# Altium schematic sheet CPU.SchDoc(CPU)
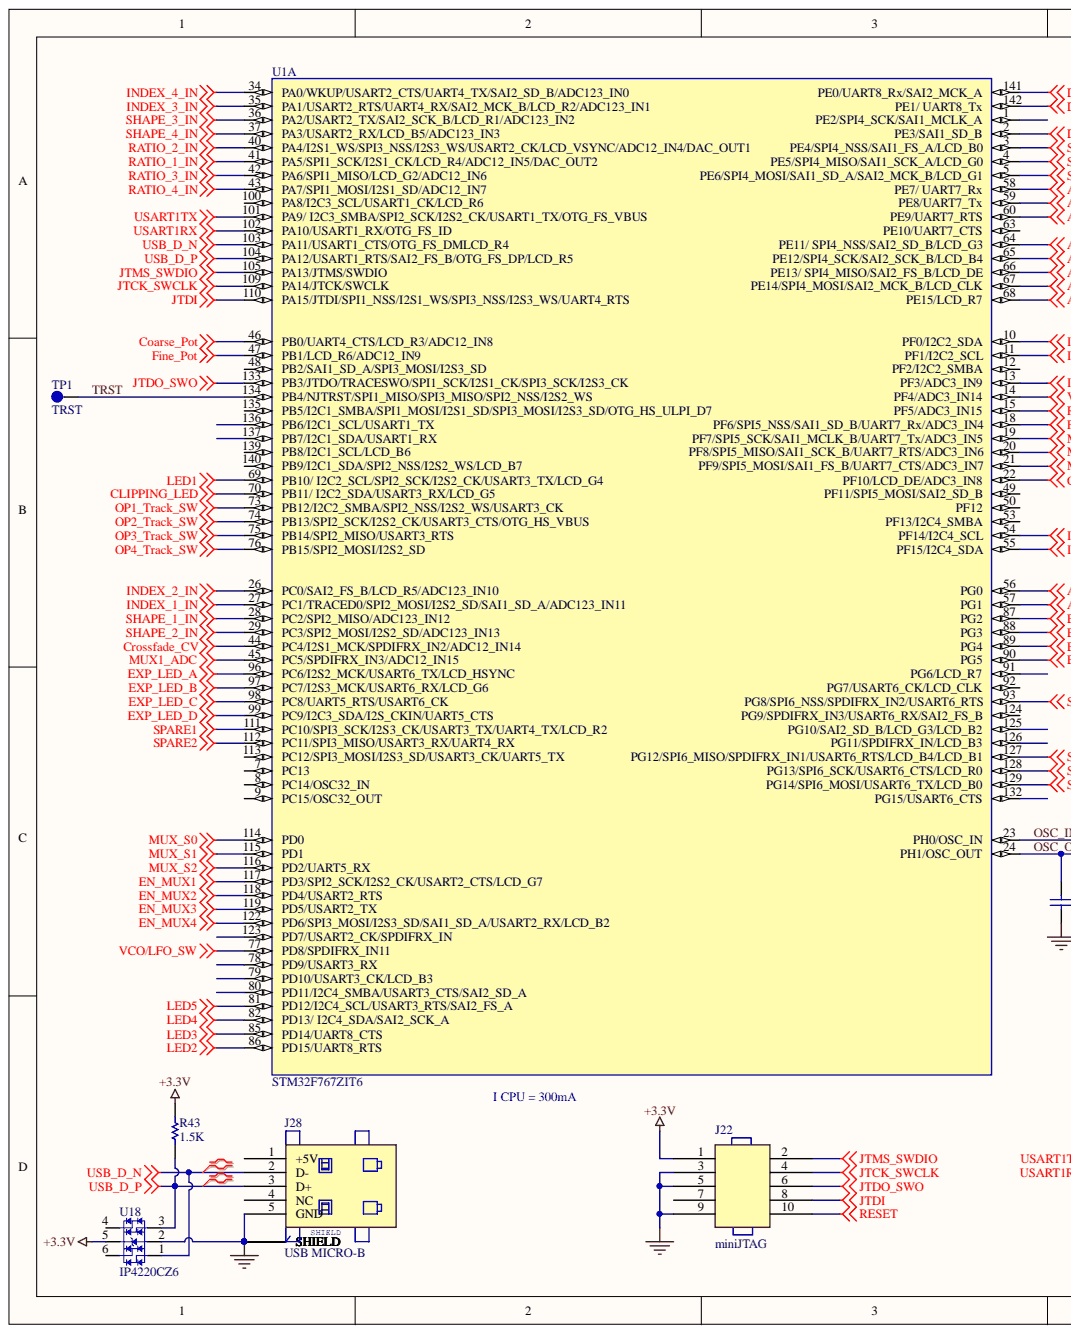
[diagram p0: CPU.SchDoc, left]
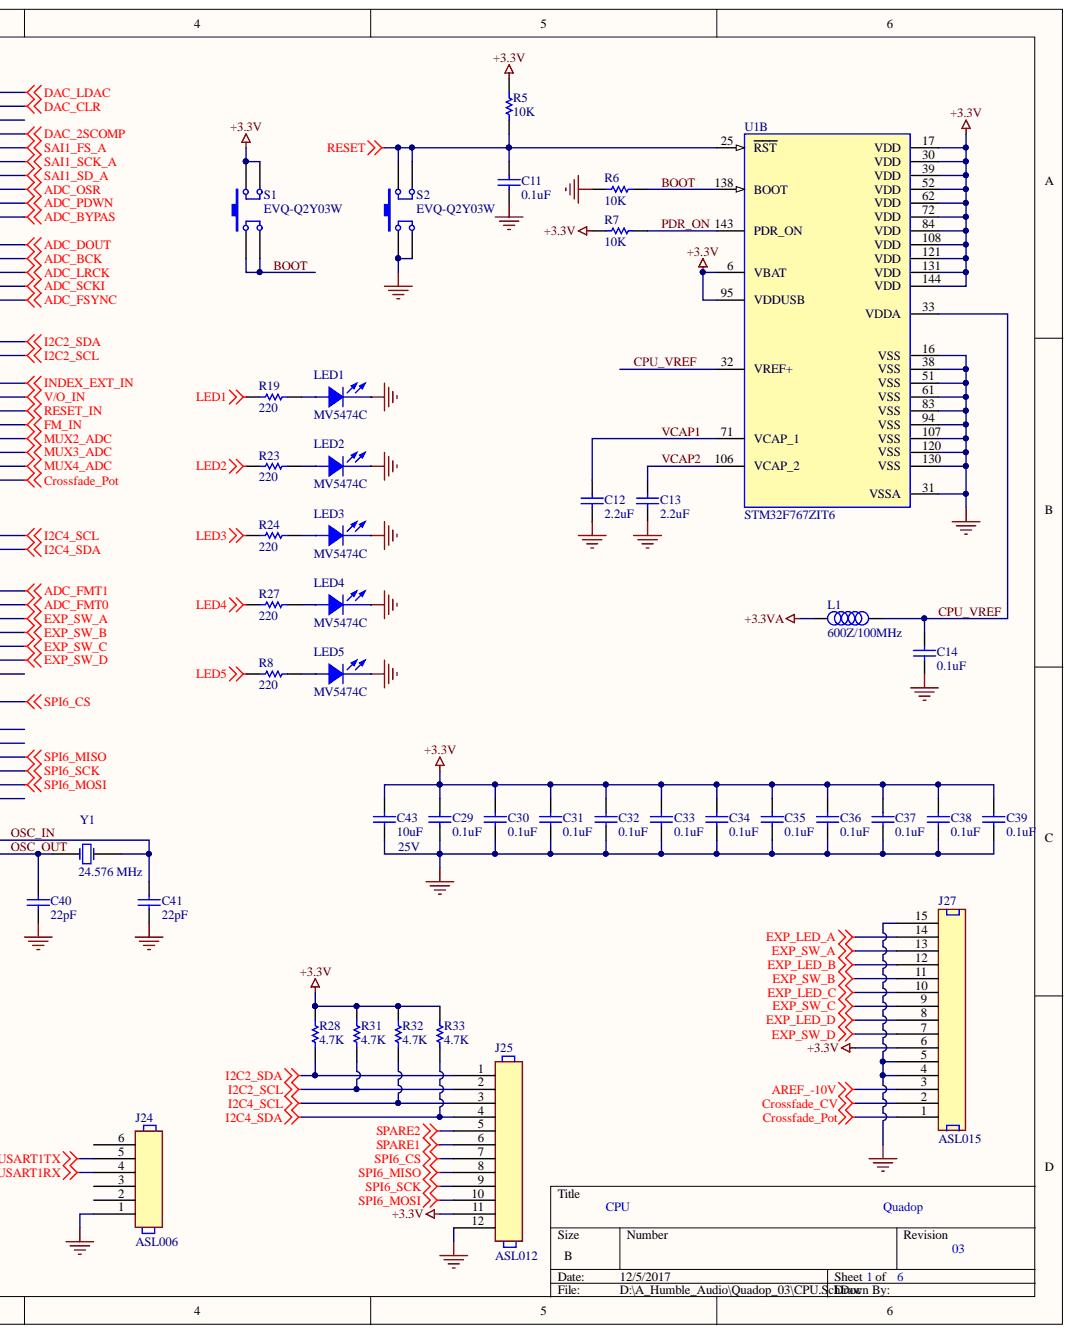
[diagram p1: CPU.SchDoc, right]

## Parts
C11  0.1uF  [C0603]
C12  2.2uF  [C0603]
C13  2.2uF  [C0603]
C14  0.1uF  [C0603]
C29  0.1uF  [C0603]
C30  0.1uF  [C0603]
C31  0.1uF  [C0603]
C32  0.1uF  [C0603]
C33  0.1uF  [C0603]
C34  0.1uF  [C0603]
C35  0.1uF  [C0603]
C36  0.1uF  [C0603]
C37  0.1uF  [C0603]
C38  0.1uF  [C0603]
C39  0.1uF  [C0603]
C40  22pF  [C0603]
C41  22pF  [C0603]
C43  10uF  Voltage: 25V  [C0805]
J22  miniJTAG  [HDR_1.27_2x5]
J24  ASL006  [SL1X6]
J25  ASL012  [SL1X12]
J27  ASL015  [SL1X15]
J28  USB MICRO-B  Part Type: USB  [Molex_1050170001]
L1  600Z/100MHz  [L1608]
LED1  MV5474C  [VDLED2_5XF3.2]
LED2  MV5474C  [VDLED2_5XF3.2]
LED3  MV5474C  [VDLED2_5XF3.2]
LED4  MV5474C  [VDLED2_5XF3.2]
LED5  MV5474C  [VDLED2_5XF3.2]
R5  10K  [R0603]
R6  10K  [R0603]
R7  10K  [R0603]
R8  220  [R0603]
R19  220  [R0603]
R23  220  [R0603]
R24  220  [R0603]
R27  220  [R0603]
R28  4.7K  [R0603]
R31  4.7K  [R0603]
R32  4.7K  [R0603]
R33  4.7K  [R0603]
R43  1.5K  [R0603]
S1  EVQ-Q2Y03W  [TACT_EVQQ2_3.1mm]
S2  EVQ-Q2Y03W  [TACT_EVQQ2_3.1mm]
TP1  TRST  [TP_D0.6_SMD]
U1  STM32F767ZIT6  [LQFP144_0.5_20X20]  (parts A,B)
U18  IP4220CZ6  [SC74]
Y1  24.576 MHz  [Y2_5X3.2]

## Nets
+3.3V: C29.1 C30.1 C31.1 C32.1 C33.1 C34.1 C35.1 C36.1 C37.1 C38.1 C39.1 C43.1 J22.1 J25.11 J27.6 R5.1 R7.2 R28.1 R31.1 R32.1 R33.1 R43.1 S1.3 S1.4 U1.6(VBAT) U1.17(VDD) U1.30(VDD) U1.39(VDD) U1.52(VDD) U1.62(VDD) U1.72(VDD) U1.84(VDD) U1.95(VDDUSB) U1.108(VDD) U1.121(VDD) U1.131(VDD) U1.144(VDD) U18.5
+3.3VA: L1.1
ADC_BCK: U1.65(PE12/SPI4_SCK/SAI2_SCK_B/LCD_B4)
ADC_BYPAS: U1.60(PE9/UART7_RTS)
ADC_DOUT: U1.64(PE11/_SPI4_NSS/SAI2_SD_B/LCD_G3)
ADC_FMT0: U1.57(PG1)
ADC_FMT1: U1.56(PG0)
ADC_FSYNC: U1.68(PE15/LCD_R7)
ADC_LRCK: U1.66(PE13/_SPI4_MISO/SAI2_FS_B/LCD_DE)
ADC_OSR: U1.58(PE7/_UART7_Rx)
ADC_PDWN: U1.59(PE8/UART7_Tx)
ADC_SCKI: U1.67(PE14/SPI4_MOSI/SAI2_MCK_B/LCD_CLK)
AREF_-10V: J27.3
CLIPPING_LED: U1.70(PB11/_I2C2_SDA/USART3_RX/LCD_G5)
Coarse_Pot: U1.46(PB0/UART4_CTS/LCD_R3/ADC12_IN8)
Crossfade_CV: J27.2 U1.44(PC4/I2S1_MCK/SPDIFRX_IN2/ADC12_IN14)
Crossfade_Pot: J27.1 U1.22(PF10/LCD_DE/ADC3_IN8)
DAC_2SCOMP: U1.2(PE3/SAI1_SD_B)
DAC_CLR: U1.142(PE1/_UART8_Tx)
DAC_LDAC: U1.141(PE0/UART8_Rx/SAI2_MCK_A)
EN_MUX1: U1.117(PD3/SPI2_SCK/I2S2_CK/USART2_CTS/LCD_G7)
EN_MUX2: U1.118(PD4/USART2_RTS)
EN_MUX3: U1.119(PD5/USART2_TX)
EN_MUX4: U1.122(PD6/SPI3_MOSI/I2S3_SD/SAI1_SD_A/USART2_RX/LCD_B2)
EXP_LED_A: J27.14 U1.96(PC6/I2S2_MCK/USART6_TX/LCD_HSYNC)
EXP_LED_B: J27.12 U1.97(PC7/I2S3_MCK/USART6_RX/LCD_G6)
EXP_LED_C: J27.10 U1.98(PC8/UART5_RTS/USART6_CK)
EXP_LED_D: J27.8 U1.99(PC9/I2C3_SDA/I2S_CKIN/UART5_CTS)
EXP_SW_A: J27.13 U1.87(PG2)
EXP_SW_B: J27.11 U1.88(PG3)
EXP_SW_C: J27.9 U1.89(PG4)
EXP_SW_D: J27.7 U1.90(PG5)
Fine_Pot: U1.47(PB1/LCD_R6/ADC12_IN9)
FM_IN: U1.18(PF6/SPI5_NSS/SAI1_SD_B/UART7_Rx/ADC3_IN4)
GND: C11.2 C12.2 C13.2 C14.2 C29.2 C30.2 C31.2 C32.2 C33.2 C34.2 C35.2 C36.2 C37.2 C38.2 C39.2 C40.2 C41.2 C43.2 J22.3 J22.5 J22.9 J24.1 J25.12 J27.4 J27.5 J27.15 J28.5(GND) J28.6 J28.7 J28.8 J28.9 J28.10(SHIELD) J28.11 LED1.2 LED2.2 LED3.2 LED4.2 LED5.2 R6.2 S2.1 S2.2 U1.16(VSS) U1.31(VSSA) U1.38(VSS) U1.51(VSS) U1.61(VSS) U1.83(VSS) U1.94(VSS) U1.107(VSS) U1.120(VSS) U1.130(VSS) U18.2
I2C2_SCL: J25.2 R31.2 U1.11(PF1/I2C2_SCL)
I2C2_SDA: J25.1 R28.2 U1.10(PF0/I2C2_SDA)
I2C4_SCL: J25.3 R32.2 U1.54(PF14/I2C4_SCL)
I2C4_SDA: J25.4 R33.2 U1.55(PF15/I2C4_SDA)
INDEX_1_IN: U1.27(PC1/TRACED0/SPI2_MOSI/I2S2_SD/SAI1_SD_A/ADC123_IN11)
INDEX_2_IN: U1.26(PC0/SAI2_FS_B/LCD_R5/ADC123_IN10)
INDEX_3_IN: U1.35(PA1/USART2_RTS/UART4_RX/SAI2_MCK_B/LCD_R2/ADC123_IN1)
INDEX_4_IN: U1.34(PA0/WKUP/USART2_CTS/UART4_TX/SAI2_SD_B/ADC123_IN0)
INDEX_EXT_IN: U1.13(PF3/ADC3_IN9)
JTCK_SWCLK: J22.4 U1.109(PA14/JTCK/SWCLK)
JTDI: J22.8 U1.110(PA15/JTDI/SPI1_NSS/I2S1_WS/SPI3_NSS/I2S3_WS/UART4_RTS)
JTDO_SWO: J22.6 U1.133(PB3/JTDO/TRACESWO/SPI1_SCK/I2S1_CK/SPI3_SCK/I2S3_CK)
JTMS_SWDIO: J22.2 U1.105(PA13/JTMS/SWDIO)
LED1: R19.1 U1.69(PB10/_I2C2_SCL/SPI2_SCK/I2S2_CK/USART3_TX/LCD_G4)
LED2: R23.1 U1.86(PD15/UART8_RTS)
LED3: R24.1 U1.85(PD14/UART8_CTS)
LED4: R27.1 U1.82(PD13/_I2C4_SDA/SAI2_SCK_A)
LED5: R8.1 U1.81(PD12/I2C4_SCL/USART3_RTS/SAI2_FS_A)
MUX1_ADC: U1.45(PC5/SPDIFRX_IN3/ADC12_IN15)
MUX2_ADC: U1.19(PF7/SPI5_SCK/SAI1_MCLK_B/UART7_Tx/ADC3_IN5)
MUX3_ADC: U1.20(PF8/SPI5_MISO/SAI1_SCK_B/UART7_RTS/ADC3_IN6)
MUX4_ADC: U1.21(PF9/SPI5_MOSI/SAI1_FS_B/UART7_CTS/ADC3_IN7)
MUX_S0: U1.114(PD0)
MUX_S1: U1.115(PD1)
MUX_S2: U1.116(PD2/UART5_RX)
OP1_Track_SW: U1.73(PB12/I2C2_SMBA/SPI2_NSS/I2S2_WS/USART3_CK)
OP2_Track_SW: U1.74(PB13/SPI2_SCK/I2S2_CK/USART3_CTS/OTG_HS_VBUS)
OP3_Track_SW: U1.75(PB14/SPI2_MISO/USART3_RTS)
OP4_Track_SW: U1.76(PB15/SPI2_MOSI/I2S2_SD)
RATIO_1_IN: U1.41(PA5/SPI1_SCK/I2S1_CK/LCD_R4/ADC12_IN5/DAC_OUT2)
RATIO_2_IN: U1.40(PA4/I2S1_WS/SPI3_NSS/I2S3_WS/USART2_CK/LCD_VSYNC/ADC12_IN4/DAC_OUT1)
RATIO_3_IN: U1.42(PA6/SPI1_MISO/LCD_G2/ADC12_IN6)
RATIO_4_IN: U1.43(PA7/SPI1_MOSI/I2S1_SD/ADC12_IN7)
RESET: C11.1 J22.10 R5.2 S2.3 S2.4 U1.25(RST)
RESET_IN: U1.15(PF5/ADC3_IN15)
SAI1_FS_A: U1.3(PE4/SPI4_NSS/SAI1_FS_A/LCD_B0)
SAI1_SCK_A: U1.4(PE5/SPI4_MISO/SAI1_SCK_A/LCD_G0)
SAI1_SD_A: U1.5(PE6/SPI4_MOSI/SAI1_SD_A/SAI2_MCK_B/LCD_G1)
SHAPE_1_IN: U1.28(PC2/SPI2_MISO/ADC123_IN12)
SHAPE_2_IN: U1.29(PC3/SPI2_MOSI/I2S2_SD/ADC123_IN13)
SHAPE_3_IN: U1.36(PA2/USART2_TX/SAI2_SCK_B/LCD_R1/ADC123_IN2)
SHAPE_4_IN: U1.37(PA3/USART2_RX/LCD_B5/ADC123_IN3)
SPARE1: J25.6 U1.111(PC10/SPI3_SCK/I2S3_CK/USART3_TX/UART4_TX/LCD_R2)
SPARE2: J25.5 U1.112(PC11/SPI3_MISO/USART3_RX/UART4_RX)
SPI6_CS: J25.7 U1.93(PG8/SPI6_NSS/SPDIFRX_IN2/USART6_RTS)
SPI6_MISO: J25.8 U1.127(PG12/SPI6_MISO/SPDIFRX_IN1/USART6_RTS/LCD_B4/LCD_B1)
SPI6_MOSI: J25.10 U1.129(PG14/SPI6_MOSI/USART6_TX/LCD_B0)
SPI6_SCK: J25.9 U1.128(PG13/SPI6_SCK/USART6_CTS/LCD_R0)
USART1RX: J24.4 U1.102(PA10/USART1_RX/OTG_FS_ID)
USART1TX: J24.5 U1.101(PA9/_I2C3_SMBA/SPI2_SCK/I2S2_CK/USART1_TX/OTG_FS_VBUS)
USB_D_N: J28.2(D-) U1.103(PA11/USART1_CTS/OTG_FS_DMLCD_R4) U18.1
USB_D_P: J28.3(D+) R43.2 U1.104(PA12/USART1_RTS/SAI2_FS_B/OTG_FS_DP/LCD_R5) U18.3
V/O_IN: U1.14(PF4/ADC3_IN14)
VCO/LFO_SW: U1.77(PD8/SPDIFRX_IN11)
BOOT: R6.1 S1.1 S1.2 U1.138(BOOT)
CPU_VREF: C14.1 L1.2 U1.32(VREF+) U1.33(VDDA)
OSC_IN: C41.1 U1.23(PH0/OSC_IN) Y1.2
OSC_OUT: C40.1 U1.24(PH1/OSC_OUT) Y1.1
PDR_ON: R7.1 U1.143(PDR_ON)
TRST: TP1.1 U1.134(PB4/NJTRST/SPI1_MISO/SPI3_MISO/SPI2_NSS/I2S2_WS)
VCAP1: C12.1 U1.71(VCAP_1)
VCAP2: C13.1 U1.106(VCAP_2)
NetLED1_1: LED1.1 R19.2
NetLED2_1: LED2.1 R23.2
NetLED3_1: LED3.1 R24.2
NetLED4_1: LED4.1 R27.2
NetLED5_1: LED5.1 R8.2

Not connected: J22 7; J24 2 3 6; J28 1(+5V) 4(NC); U1 1(PE2/SPI4_SCK/SAI1_MCLK_A) 7(PC13) 8(PC14/OSC32_IN) 9(PC15/OSC32_OUT) 12(PF2/I2C2_SMBA) 48(PB2/SAI1_SD_A/SPI3_MOSI/I2S3_SD) 49(PF11/SPI5_MOSI/SAI2_SD_B) 50(PF12) 53(PF13/I2C4_SMBA) 63(PE10/UART7_CTS) 78(PD9/USART3_RX) 79(PD10/USART3_CK/LCD_B3) 80(PD11/I2C4_SMBA/USART3_CTS/SAI2_SD_A) 91(PG6/LCD_R7) 92(PG7/USART6_CK/LCD_CLK) 100(PA8/I2C3_SCL/USART1_CK/LCD_R6) 113(PC12/SPI3_MOSI/I2S3_SD/USART3_CK/UART5_TX) 123(PD7/USART2_CK/SPDIFRX_IN) 124(PG9/SPDIFRX_IN3/USART6_RX/SAI2_FS_B) 125(PG10/SAI2_SD_B/LCD_G3/LCD_B2) 126(PG11/SPDIFRX_IN/LCD_B3) 132(PG15/USART6_CTS) 135(PB5/I2C1_SMBA/SPI1_MOSI/I2S1_SD/SPI3_MOSI/I2S3_SD/OTG_HS_ULPI_D7) 136(PB6/I2C1_SCL/USART1_TX) 137(PB7/I2C1_SDA/USART1_RX) 139(PB8/I2C1_SCL/LCD_B6) 140(PB9/I2C1_SDA/SPI2_NSS/I2S2_WS/LCD_B7); U18 4 6

## Library data of the parts
C11 C14 C29-C39 = 00000538: 100nF 50V +-10% X7R 0603 -55+125; Manufacturer Part Number: GRM188R71H104KA93J; Manufacturer: MURATA; PSpice: C; Lead time: Stock; Database Table Name: Capacitor; Voltage: 50V; Value: 0.1uF; Tolerance: +-10%; Tape Width: 8mm; SMD: Yes; Price: 0.0048; Part Type: Ceramic; Part Number: 00000538; Package: 10000T&R330mm; MOQ: 4000; Main distributor: Rutronik; Distributor: Rutronik; Component Kind: Standard; Availability: In Stock; ActivepartsID: http://search.murata.co.jp/Ceramy/GrsearchViewAction.do;jsessionid=C4D720578E4D2E51EC807AFF78C1B5C3; Library Name: Gedo_DB.DbLib
C12 C13 = 00225162: 2.2uF 16V 20% Y5V 0603 -30+85; Manufacturer Part Number: EMK107F225ZA-T; Manufacturer: Taiyo Yuden; Tolerance: +-20%; PSpice: C; Database Table Name: Capacitor; Voltage: 16V; Value: 2.2uF; Tape Width: 8mm; SMD: Yes; Price: 0.038; Part Type: Ceramic; Part Number: 00225162; Package: 4000T&R; Distributor Part Number: 587-1263-1-ND; Distributor: Digi-Key; Component Kind: Standard; Library Name: Gedo_DB.DbLib
C40 C41 = 00220502: 22pF 50V 5% C0G 0603 -55+125; Manufacturer Part Number: C1608C0G1H220J080AA; Manufacturer: TDK; Tolerance: +-5%; PSpice: C; Database Table Name: Capacitor; Voltage: 50V; Value: 22pF; Tape Width: 8mm; SMD: Yes; Price: 0.0138; Part Type: Ceramic; Part Number: 00220502; Package: 4000T&R; Distributor Part Number: 445-1273-1-ND; Distributor: Digi-Key; Component Kind: Standard; Library Name: Gedo_DB.DbLib
C43 = 00106253: 10uF 25V 10% X5R 0805 -55+85; Manufacturer Part Number: TMK212BBJ106KG-T; Manufacturer: Taiyo Yuden; Tolerance: +-10%; PSpice: C; Database Table Name: Capacitor; Value: 10uF; Tape Width: 8mm; SMD: Yes; Price: 0.385; Part Type: Ceramic; Part Number: 00106253; Package: 3000T&R; Distributor Part Number: 587-2985-1-ND; Distributor: Digi-Key; Component Kind: Standard; Library Name: Gedo_DB.DbLib
J22 = 70300212: Header 5x2_1.27mm male, 125V,1A; Manufacturer Part Number: 20021111-00010T4LF; Manufacturer: FCI; Database Table Name: Connectors; Value: miniJTAG; Rating: 125V; Price: 0.6; Part Type: 1.27 header; Part Number: 70300212; Distributor Part Number: 20021111-00010T4LF; Distributor: Digi-Key; Component Kind: Standard; Library Name: Gedo_DB.DbLib
J24 = 71001106: Header 6x2,54mm 250V,3A; Database Table Name: Connectors; Value: ASL006; Rating: 250V; Price: 0; Part Type: 2.54 header; Part Number: 71001106; Distributor Part Number: G5224 ASL050; Distributor: SCHUKAT; Component Kind: Standard; Library Name: Gedo_DB.DbLib
J25 = 71001112: Header 12x2,54mm 250V,3A; Database Table Name: Connectors; Value: ASL012; Rating: 250V; Price: 0; Part Type: 2.54 header; Part Number: 71001112; Distributor Part Number: G5224 ASL050; Distributor: SCHUKAT; Component Kind: Standard; Library Name: Gedo_DB.DbLib
J27 = 71001115: Header 15x2,54mm 250V,3A; Manufacturer Part Number: PRPC015SAAN-RC; Manufacturer: Sullins Connector Solutions; Database Table Name: Connectors; Value: ASL015; Rating: 250V; Price: 0.34; Part Type: 2.54 header; Part Number: 71001115; Distributor Part Number: S1011EC-15-ND; Distributor: Digi-Key; Component Kind: Standard; Library Name: Gedo_DB.DbLib
J28 = 72300032: USB Connectors MICRO USB B RECPT TOP MNT;; Manufacturer Part Number: 1050170001; Manufacturer: Molex; Value: USB MICRO-B; SMD: Yes; Price: 0.763; Part Number: 72300032; Distributor Part Number: WM1399CT-ND; Distributor: Digi-Key; Database Table Name: Connectors; Component Kind: Standard; Library Name: Gedo_DB.DbLib
L1 = 20000006: Chip Bead 600Ωs/100MHz 0.5A Rdc=0.38Ω; 0603; Manufacturer Part Number: BLM18AG601SN1D; Manufacturer: Murata; PSpice: L; Database Table Name: Inductors; Value: 600Z/100MHz; SMD: Yes; Price: 0.07; Part Type: Ferrite beads; Part Number: 20000006; Distributor Part Number: 1515679; Distributor: Farnell; Component Kind: Standard; Library Name: Gedo_DB.DbLib
LED1-LED5 = 32010223: Green Diff LED 3mm 565nm 2V@10mA 9mcd T-1; Manufacturer: Everlight Electronics Co Ltd; PSpice: D; Database Table Name: Diodai; Value: MV5474C; Price: 0.166; Part Type: LED; Part Number: 32010223; Manufacturer Part Number: MV5474C; Distributor Part Number: 1080-1115-ND; Distributor: Digi-Key; Component Kind: Standard; Library Name: Gedo_DB.DbLib
R5-R7 = 11010022: 10K 1% 0603 1/10W 100ppm; Manufacturer Part Number: RC0603FR-0710KL; Manufacturer: YAGEO; PSpice: R; Database Table Name: Resistor; Value: 10K; Tolerance: 1%; Tape Width: 8mm; SMD: Yes; Price: 0.0057; Power: 1/10W; Part type: SMD 1%; Part Number: 11010022; Package: 5000T&R; Distributor Part Number: 311-10.0KHRCT-ND; Distributor: Digi-Key; Component Kind: Standard; Availability: In Stock; Library Name: Gedo_DB.DbLib
R8 R19 R23 R24 R27 = 11022002: 220R 1% 0603 1/10W 100ppm; Manufacturer Part Number: RC0603FR-07220RL; Manufacturer: YAGEO; PSpice: R; Database Table Name: Resistor; Value: 220; Tolerance: 1%; Tape Width: 8mm; SMD: Yes; Price: 0.01; Power: 1/10W; Part type: SMD 1%; Part Number: 11022002; Package: 5000T&R; Distributor Part Number: 311-220HRCT-ND; Distributor: Digi-Key; Component Kind: Standard; Availability: In Stock; Library Name: Gedo_DB.DbLib
R28 R31-R33 = 11047012: 4K7 1% 0603 1/10W 100ppm; PSpice: R; Lead time: Stock; Database Table Name: Resistor; Value: 4.7K; Tolerance: 1%; Tape Width: 8mm; SMD: Yes; Power: 1/10W; Part type: SMD 1%; Part Number: 11047012; MOQ: 5000; Main distributor: Rutronik; Component Kind: Standard; Availability: In Stock; Library Name: Gedo_DB.DbLib
R43 = 11015012: 1K5 1% 0603 1/10W 100ppm; Manufacturer Part Number: RC0603FR-071K5L; Manufacturer: YAGEO; PSpice: R; Database Table Name: Resistor; Value: 1.5K; Tolerance: 1%; Tape Width: 8mm; SMD: Yes; Price: 0.013; Power: 1/10W; Part type: SMD 1%; Part Number: 11015012; Package: 7"T&R; Component Kind: Standard; Availability: In Stock; Library Name: Gedo_DB.DbLib
S1 S2 = 88002030: MINI Tact SPST OFF-MOM SMD,350gf, 6.6x6x3.1mm ,15Vdc,20mA,-40+85; Manufacturer: Panasonic Electronic Components; Database Table Name: Misc; Value: EVQ-Q2Y03W; Tape Width: 12mm; SMD: Yes; Price: 0.28; Part Type: Switch\Tact; Part Number: 88002030; Package: 2000T&R; MOQ: 0; Manufacturer Part Number: EVQ-Q2Y03W; Distributor Part Number: P12961SCT-ND; Distributor: Digi-Key; Component Kind: Standard; Library Name: Gedo_DB.DbLib
TP1 = 93000063: PCB Testpoint SMD D0.6mm   neperkamas; Database Table Name: Misc; Value: TRST; Part Type: Test Point; Part Number: 93000063; Component Kind: Standard; Library Name: Gedo_DB.DbLib
U1 = 50104767: 32 Bit CORTEX-M7,216MHz,2MB Flash,512kB SRAM,1.7-3.6V,LQFP144,-40+85; Manufacturer: STMicroelectronics; Database Table Name: IC; Value: STM32F767ZIT6; SMD: Yes; Price: 14.69; Part Type: Microprocessor/Cortex; Part Number: 50104767; Manufacturer Part Number: STM32F767ZIT6; Distributor Part Number: 497-16521-ND; Distributor: Digi-Key; Component Kind: Standard; Library Name: Gedo_DB.DbLib
U18 = 31900503: TVS diode array,+-8kV,SLP1616P6;-55+125; Manufacturer Part Number: IP4220CZ6,125; Manufacturer: NXP Semiconductors; PSpice: D; Database Table Name: Diodai; Value: IP4220CZ6; SMD: Yes; Price: 0.42; Part Type: ESD Protection; Part Number: 31900503; Package: 3000T&R; Distributor Part Number: 568-3529-1-ND; Distributor: Digi-Key; Component Kind: Standard; Library Name: Gedo_DB.DbLib
Y1 = 25000426: 24.576 MHz Crystal ,5.0x3.2x1.35mm,12pF,+-30ppm,-40+85; Manufacturer Part Number: 7A-24.576MAHE-T; Manufacturer: TXC; Database Table Name: Misc; Value: 24.576 MHz; SMD: Yes; Price: 0.63; Part Type: Quartz crystal\SMD 5.0x3.2; Part Number: 25000426; MOQ: 0; Distributor Part Number: 887-1769-1-ND; Distributor: Digi-Key; Component Kind: Standard; Library Name: Gedo_DB.DbLib
